# Altium schematic sheet Power.SchDoc
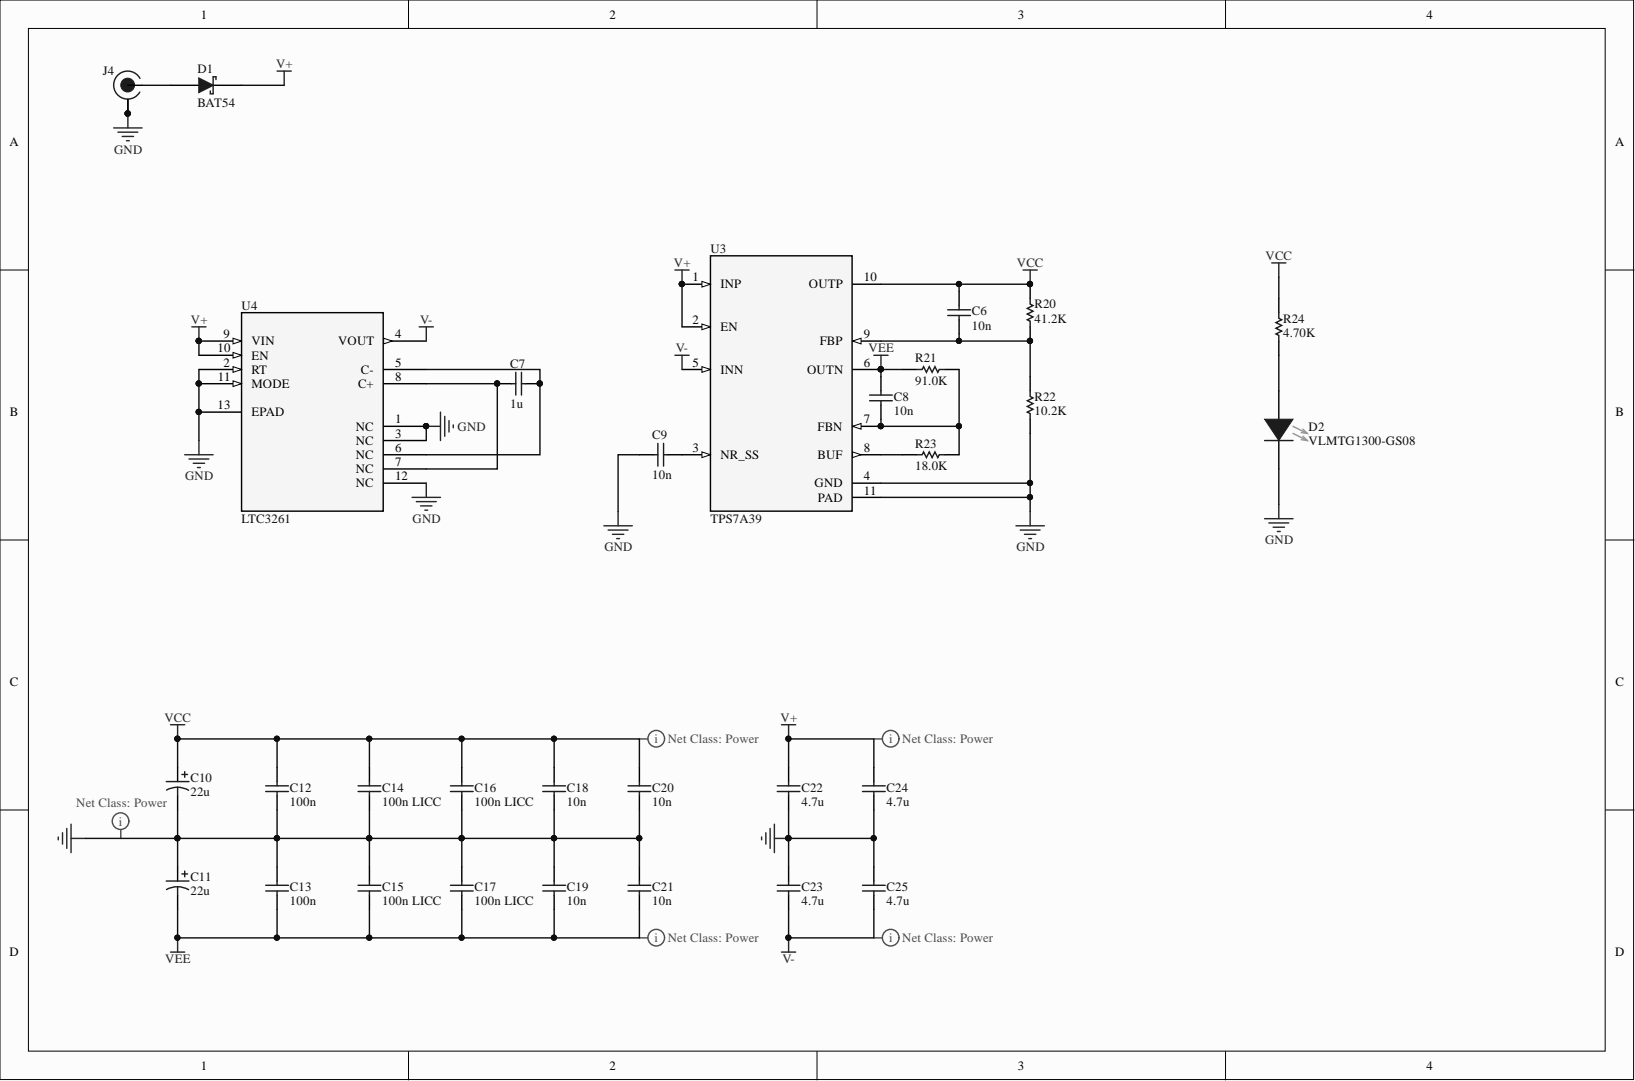
[diagram p0: Power.SchDoc]

## Parts
C6  10n  [CAPC0402(1005)50_M]
C7  1u  [CAPC0805(2012)145_M]
C8  10n  [CAPC0402(1005)50_M]
C9  10n  [CAPC0402(1005)50_M]
C10  22u  [KEMET B_M]
C11  22u  [KEMET B_M]
C12  100n  [CAPC0603(1608)100_M]
C13  100n  [CAPC0603(1608)100_M]
C14  100n LICC  [CAPC0306_M]
C15  100n LICC  [CAPC0306_M]
C16  100n LICC  [CAPC0306_M]
C17  100n LICC  [CAPC0306_M]
C18  10n  [CAPC0402(1005)50_M]
C19  10n  [CAPC0402(1005)50_M]
C20  10n  [CAPC0402(1005)50_M]
C21  10n  [CAPC0402(1005)50_M]
C22  4.7u  [CAPC0805(2012)100_M]
C23  4.7u  [CAPC0805(2012)100_M]
C24  4.7u  [CAPC0805(2012)100_M]
C25  4.7u  [CAPC0805(2012)100_M]
D1  BAT54  [INF-PG-SC59_M]
D2  VLMTG1300-GS08  [LED0603(1608)_M]
J4  2.1/5.5mm  [CUI PJ-002A]  (value not drawn)
R20  41.2K  [RESC0402(1005)_M]
R21  91.0K  [RESC0402(1005)_M]
R22  10.2K  [RESC0402(1005)_M]
R23  18.0K  [RESC0402(1005)_M]
R24  4.70K  [RESC0603(1608)_M]
U3  TPS7A39  [DSC0010J_NV]
U4  LTC3261  [MSOP-12_MSE]

## Nets
GND: C9.2 C10.2 C11.1 C12.2 C13.1 C14.2 C15.1 C16.2 C17.1 C18.2 C19.1 C20.2 C21.1 C22.2 C23.1 C24.2 C25.2 D2.2 J4.2 J4.3 R22.2 U3.4(GND) U3.11(PAD) U4.1(NC) U4.2(RT) U4.3(NC) U4.11(MODE) U4.12(NC) U4.13(EPAD)
V+: C22.1 C24.1 D1.3 U3.1(INP) U3.2(EN) U4.9(VIN) U4.10(EN)
V-: C23.2 C25.1 U3.5(INN) U4.4(VOUT)
VCC: C6.2 C10.1 C12.1 C14.1 C16.1 C18.1 C20.1 R20.1 R24.1 U3.10(OUTP)
VEE: C8.2 C11.2 C13.2 C15.2 C17.2 C19.2 C21.2 R21.2 U3.6(OUTN)
NetC6_1: C6.1 R20.2 R22.1 U3.9(FBP)
NetC7_1: C7.1 U4.7(NC) U4.8(C+)
NetC7_2: C7.2 U4.5(C-) U4.6(NC)
NetC8_1: C8.1 R21.1 R23.1 U3.7(FBN)
NetC9_1: C9.1 U3.3(NR_SS)
NetD1_1: D1.1 J4.1
NetD2_1: D2.1 R24.2
NetR23_2: R23.2 U3.8(BUF)

## Library data of the parts
C6 C8 C9 = GRM1555C1E103JE01: CAP CER 10NF±5% 25V C0G 0402; Manufacturer: Murata; Manufacturer Part Number: GRM1555C1E103JE01D; Capacitance: 10nF; Database Table Name: Murata GRM 0402$; Tolerance: ±5%; Component Kind: Standard; Library Name: Murata GRM 0402.DbLib; Part Number: GRM1555C1E103JE01; Temperature Coefficient: C0G; Value: 10n
C7 = CC0805KKX7R9BB105: Cap Ceramic 1uF 50V X7R 10% SMD 0805 125C Blister T/R; Manufacturer: Yageo; Capacitance: 1uF; Case/Package: 0805; Case Code (Imperial): 0805; Case Code (Metric): 2012; Component Kind: Standard; ComponentLink1Description: http://www.mouser.com/ds/2/447/Yageo_UPY-GP_NP0_16V-to-50V_9-358835.pdf?src-supplier=Mouser; ComponentLink1URL: http://www.mouser.com/ds/2/447/Yageo_UPY-GP_NP0_16V-to-50V_9-358835.pdf?src-supplier=Mouser; Composition: Ceramic; Depth: 1.25mm; Dielectric: X7R; Halogen Free: Halogen Free; Height: 1.25mm; Lead Free: Lead Free; Length: 2mm; Library Name: 0805 Caps.SchLib; Manufacturer Part Number: CC0805KKX7R9BB105; Max Operating Temperature: 125°C; Min Operating Temperature: -55°C; Mount: Surface Mount; Packaging: Tape and Reel; Pricing 1: 1=0.27, 10=0.188, 100=0.1064, 500=0.0751, 1000=0.06571 (USD); Radiation Hardening: No; Stock 1: 558291; Supplier 1: Digi-Key; Supplier 2: Mouser; Supplier Part Number 1: 311-1886-1-ND; Supplier Part Number 2: 603-CC805KKX7R9BB105; Termination: SMD/SMT; Thickness: 0.057inch; Tolerance: 10%; Voltage Rating: 50V; Voltage Rating (DC): 50V; Weight: 0.000194oz; Width: 0.049inch
C10 C11 = T491B226K016AT: CAP TANT 22UF 10% 16V 1411; Manufacturer: KEMET; Dielectric Material: Tantalum; Dissipation Factor: 6%; ESR (Equivalent Series Resistance): 2.2R; Leakage Current: 3.6uA; Life (Hours): 2000Hour; Polarity: Polar; Ripple Current: 197mA; Capacitance: 22uF; Case/Package: 1411; Case Code (Imperial): 1411; Case Code (Metric): 3528; Component Kind: Standard; ComponentLink1Description: https://datasheet.ciiva.com/8079/kem-t2005-t491-8079799.pdf?src-supplier=Element14; ComponentLink1URL: https://datasheet.ciiva.com/8079/kem-t2005-t491-8079799.pdf?src-supplier=Element14; ComponentLink2Description: https://datasheet.ciiva.com/7696/1854511-7696325.pdf?src-supplier=Element14; ComponentLink2URL: https://datasheet.ciiva.com/7696/1854511-7696325.pdf?src-supplier=Element14; ComponentLink3Description: https://datasheet.ciiva.com/7696/1446014-7696326.pdf?src-supplier=Element14; ComponentLink3URL: https://datasheet.ciiva.com/7696/1446014-7696326.pdf?src-supplier=Element14; ComponentLink4Description: https://datasheet.ciiva.com/5934/1446014-5934574.pdf?src-supplier=Farnell; ComponentLink4URL: https://datasheet.ciiva.com/5934/1446014-5934574.pdf?src-supplier=Farnell; ComponentLink5Description: https://datasheet.ciiva.com/6247/kem-t2005-t491-6247978.pdf?src-supplier=Digikey; ComponentLink5URL: https://datasheet.ciiva.com/6247/kem-t2005-t491-6247978.pdf?src-supplier=Digikey; ComponentLink6Description: https://datasheet.ciiva.com/6247/kem-t2005-t491-6247977.pdf?src-supplier=Digikey; ComponentLink6URL: https://datasheet.ciiva.com/6247/kem-t2005-t491-6247977.pdf?src-supplier=Digikey; ComponentLink7Description: https://datasheet.ciiva.com/6247/kem-t2005-t491-6247979.pdf?src-supplier=Digikey; ComponentLink7URL: https://datasheet.ciiva.com/6247/kem-t2005-t491-6247979.pdf?src-supplier=Digikey; ComponentLink8Description: https://datasheet.ciiva.com/14589/70095774-14589317.pdf?src-supplier=Allied+Electronics; ComponentLink8URL: https://datasheet.ciiva.com/14589/70095774-14589317.pdf?src-supplier=Allied+Electronics; ComponentLink9Description: https://datasheet.ciiva.com/14600/70095774-14600348.pdf?src-supplier=Allied+Electronics; ComponentLink9URL: https://datasheet.ciiva.com/14600/70095774-14600348.pdf?src-supplier=Allied+Electronics; ComponentLink10Description: https://datasheet.ciiva.com/12911/kem-t2005-t491-12911727.pdf?src-supplier=Mouser; ComponentLink10URL: https://datasheet.ciiva.com/12911/kem-t2005-t491-12911727.pdf?src-supplier=Mouser; ComponentLink11Description: https://datasheet.ciiva.com/29244/458294-da-01-en-tantal-kondensator-t491b226k016at-29244581.pdf?src-supplier=Conrad; ComponentLink11URL: https://datasheet.ciiva.com/29244/458294-da-01-en-tantal-kondensator-t491b226k016at-29244581.pdf?src-supplier=Conrad; Construction: Flat; Depth: 2.8mm; Failure Rate: 0.001%; Features: General Purpose; Height: 1.9mm; Height - Seated (Max): 0.083inch; Lead Free: Lead Free; Lead Pitch: 1.9mm; Length: 3.5mm; Library Name: Misc Caps.SchLib; Manufacturer Part Number: T491B226K016AT; Max Operating Temperature: 125°C; Min Operating Temperature: -55°C; Mount: Surface Mount,PCB; Number of Pins: 2; Package Quantity: 1; Packaging: Tape and Reel; Pricing 1: 1=0.64, 10=0.433, 50=0.3444, 100=0.2844, 500=0.2222, 1000=0.18887 (USD); Radiation Hardening: No; Reach SVHC Compliant: Yes; RoHS Compliant: Yes; Series: T491; Stock 1: 3174; Supplier 1: Digikey; Supplier 2: Mouser; Supplier Part Number 1: 399-8312-6-ND; Supplier Part Number 2: 80-T491B226K016; Termination: SMD/SMT; Tolerance: 10%; Voltage: 16V; Voltage Rating: 16V; Voltage Rating (DC): 16V; Weight: 0.002293oz; Width: 2.8mm
C12 C13 = CC0603KRX7R9BB104: CAPACITOR CERAMIC, 0.1UF, 50V, X7R, 10%, 0603; Manufacturer: Yageo; Capacitance: 100nF; Case/Package: 0603; Case Code (Imperial): 0603; Case Code (Metric): 1608; Component Kind: Standard; ComponentLink1Description: http://www.yageo.com/documents/recent/UPY-GPHC_X7R_6.3V-to-50V_18.pdf?src-supplier=Digi-Key; ComponentLink1URL: http://www.yageo.com/documents/recent/UPY-GPHC_X7R_6.3V-to-50V_18.pdf?src-supplier=Digi-Key; ComponentLink2Description: http://www.farnell.com/datasheets/1489800.pdf?src-supplier=Element14; ComponentLink2URL: http://www.farnell.com/datasheets/1489800.pdf?src-supplier=Element14; ComponentLink3Description: http://www.yageo.com/documents/recent/UPY-GPHC_X7R_6.3V-to-50V_8.pdf?src-supplier=Avnet+Europe; ComponentLink3URL: http://www.yageo.com/documents/recent/UPY-GPHC_X7R_6.3V-to-50V_8.pdf?src-supplier=Avnet+Europe; ComponentLink4Description: http://www.farnell.com/datasheets/2167236.pdf?src-supplier=Element14; ComponentLink4URL: http://www.farnell.com/datasheets/2167236.pdf?src-supplier=Element14; ComponentLink5Description: http://www.farnell.com/datasheets/1489800.pdf?src-supplier=Farnell; ComponentLink5URL: http://www.farnell.com/datasheets/1489800.pdf?src-supplier=Farnell; Depth: 0.8mm; Dielectric: X7R; Dielectric Material: Ceramic; Halogen Free: Halogen Free; Height: 0.8mm; LCSC PART #: C14663; Lead Free: Lead Free; Length: 1.6mm; Library Name: 0603 Caps.SchLib; Manufacturer Part Number: CC0603KRX7R9BB104; Max Operating Temperature: 125°C; Min Operating Temperature: -55°C; Mount: Surface Mount; Number of Pins: 2; Package Quantity: 1; Packaging: Tape and Reel; Pricing 1: 1=0.1, 10=0.066, 50=0.036, 100=0.0293, 500=0.02094, 1000=0.01646 (USD); Radiation Hardening: No; REACH SVHC: No SVHC; Stock 1: 0; Supplier 1: Digi-Key; Supplier 2: Mouser; Supplier Part Number 1: 311-1344-1-ND; Supplier Part Number 2: 603-CC603KRX7R9BB104; Termination: SMD/SMT; Thickness: 0.035inch; Tolerance: 10%; Voltage: 50V; Voltage Rating: 50V; Width: 0.031inch
C14-C17 = TWK107B7104MVHT: CAP CER 0.1UF 25V X7R 0306; Manufacturer: Taiyo Yuden; Capacitance: 100nF; Tolerance: 20%; Case/Package: 0306; Case Code (Imperial): 0306; Case Code (Metric): 0816; Component Kind: Standard; ComponentLink1Description: http://ds.yuden.co.jp/TYCOMPAS/ut/detail.do?productNo=TWK107B7104MVHT&amp;fileName=TWK107B7104MVHT_SS&amp;mode=specSheetDownload&src-supplier=Digi-Key; ComponentLink1URL: http://ds.yuden.co.jp/TYCOMPAS/ut/detail.do?productNo=TWK107B7104MVHT&amp;fileName=TWK107B7104MVHT_SS&amp;mode=specSheetDownload&src-supplier=Digi-Key; ComponentLink2Description: https://ds.yuden.co.jp/TYCOMPAS/ut/detail?pn=TWK107B7104MVHT &amp;u=M&src-supplier=Digi-Key; ComponentLink2URL: https://ds.yuden.co.jp/TYCOMPAS/ut/detail?pn=TWK107B7104MVHT &amp;u=M&src-supplier=Digi-Key; Library Name: Misc Caps.SchLib; Manufacturer Part Number: TWK107B7104MVHT; Max Operating Temperature: 125°C; Min Operating Temperature: -55°C; Mount: Surface Mount; Packaging: Tape and Reel; Pricing 1: 1=0.31, 10=0.219, 50=0.153, 100=0.1312, 500=0.0984, 1000=0.08309 (USD); Ratings: AEC-Q200; RoHS Compliant: Yes; Stock 1: 9611; Supplier 1: Digi-Key; Supplier 2: Mouser; Supplier Part Number 1: 587-6181-1-ND; Supplier Part Number 2: 963-TWK107B7104MVHT; Voltage Rating: 25V
C18-C21 = GRM155R71H103KA88: CAP CER 10NF±10% 50V X7R 0402; Manufacturer: Murata; Manufacturer Part Number: GRM155R71H103KA88D; Capacitance: 10nF; Database Table Name: Murata GRM 0402$; Tolerance: ±10%; Component Kind: Standard; Library Name: Murata GRM 0402.DbLib; Part Number: GRM155R71H103KA88; Temperature Coefficient: X7R; Value: 10n
C22-C25 = GRM21BZ71H475KE15K: CAP CER 4.7UF 50V X7R 0805; Manufacturer: Murata; Component Kind: Standard; ComponentLink1Description: https://datasheet.octopart.com/GRM21BZ71H475KE15K-Murata-datasheet-149523520.pdf?src-supplier=Newark; ComponentLink1URL: https://datasheet.octopart.com/GRM21BZ71H475KE15K-Murata-datasheet-149523520.pdf?src-supplier=Newark; ComponentLink2Description: https://datasheet.octopart.com/GRM21BZ71H475KE15K-Murata-datasheet-165579666.pdf?src-supplier=TME; ComponentLink2URL: https://datasheet.octopart.com/GRM21BZ71H475KE15K-Murata-datasheet-165579666.pdf?src-supplier=TME; Library Name: 0805 Caps.SchLib; Manufacturer Part Number: GRM21BZ71H475KE15K; Pricing 1: 1=0.6, 10=0.444, 50=0.3554, 100=0.3047, 500=0.2412, 1000=0.20311, 2500=0.19042, 5000=0.17772 (USD); RoHS Compliant: Yes; Stock 1: 134344; Supplier 1: Digi-Key; Supplier 2: Mouser; Supplier Part Number 1: 490-GRM21BZ71H475KE15KCT-ND; Supplier Part Number 2: 81-GRM21BZ71H475KE5K
D1 = BAT54: DIODE SCHOTTKY 30V 200MA TO236AB; Manufacturer: Nexperia USA; Manufacturer Part Number: BAT54,215; Component Kind: Standard; Library Name: Discretes.SchLib; Stock 1: 0; Supplier 1: Digi-Key; Supplier Part Number 1: 1727-2900-6-ND
D2 = VLMTG1300-GS08: LED GREEN 0603 SMD; Manufacturer: Vishay Semiconductors; Dominant Wavelength: 525nm; Forward Current: 20mA; Forward Voltage: 3.2V; Lens Style: Rectangular,Clear; Luminous Intensity: 450mcd; Max Power Dissipation: 76mW; Number of Elements: 1; Power Rating: 75mW; Viewing Angle: 130°; Case/Package: 0603; Case Code (Imperial): 0603; Case Code (Metric): 1608; Color: Green; Component Kind: Standard; ComponentLink1Description: https://datasheet.ciiva.com/26322/vlmo1300-26322149.pdf?src-supplier=Element14; ComponentLink1URL: https://datasheet.ciiva.com/26322/vlmo1300-26322149.pdf?src-supplier=Element14; ComponentLink2Description: https://datasheet.ciiva.com/8028/vlmo1300-8028077.pdf?src-supplier=Element14; ComponentLink2URL: https://datasheet.ciiva.com/8028/vlmo1300-8028077.pdf?src-supplier=Element14; ComponentLink3Description: https://datasheet.ciiva.com/16510/vlmo1300-16510989.pdf?src-supplier=Future+Electronics; ComponentLink3URL: https://datasheet.ciiva.com/16510/vlmo1300-16510989.pdf?src-supplier=Future+Electronics; ComponentLink4Description: https://datasheet.ciiva.com/2115/vlmo1300-2115107.pdf?src-supplier=Digi-Key; ComponentLink4URL: https://datasheet.ciiva.com/2115/vlmo1300-2115107.pdf?src-supplier=Digi-Key; ComponentLink5Description: https://datasheet.ciiva.com/2115/vlmo1300-2115108.pdf?src-supplier=Digi-Key; ComponentLink5URL: https://datasheet.ciiva.com/2115/vlmo1300-2115108.pdf?src-supplier=Digi-Key; ComponentLink6Description: https://datasheet.ciiva.com/2115/vlmo1300-2115106.pdf?src-supplier=Digi-Key; ComponentLink6URL: https://datasheet.ciiva.com/2115/vlmo1300-2115106.pdf?src-supplier=Digi-Key; Contact Plating: Tin,Matte; Depth: 0.8mm; Height: 0.8mm; Illumination Color: Green; Lead Free: Lead Free; Length: 1.6mm; Library Name: Discretes.SchLib; Manufacturer Part Number: VLMTG1300-GS08; Max Operating Temperature: 85°C; Min Operating Temperature: -35°C; Mount: Surface Mount; Number of LEDs: 1; Number of Pins: 2; Package Quantity: 1; Packaging: Tape and Reel; Power Dissipation: 76mW; Pricing 1: 1=0.46, 10=0.305, 100=0.2033, 500=0.1406, 1000=0.11716 (USD); Radiation Hardening: No; RoHS Compliant: Yes; Series: CHipLED; Stock 1: 156996; Supplier 1: Digi-Key; Supplier 2: Mouser; Supplier Part Number 1: VLMTG1300-GS08CT-ND; Supplier Part Number 2: 78-VLMTG1300-GS08; Test Current: 20mA; Wavelength: 525nm; Width: 0.8mm
J4 = PJ-002A: CONN PWR JACK 2X5.5MM SOLDER; Manufacturer: CUI; Current Rating: 2.5A; Gender: Male; Insulation Resistance: 50MR; Number of Conductors: 2; Shielding: Unshielded; Voltage Rating: 24V; Color: Black; Component Kind: Standard; Connector Type: Jack; Contact Gender: Male; Contact Material: Copper Alloy,Copper; Contact Plating: Tin,Nickel; Diameter: 2.1mm; Flammability Rating: UL94 V-0; Housing Color: Black; Housing Material: Thermoplastic; Lead Free: Lead Free; Library Name: Connectors.SchLib; Manufacturer Part Number: PJ-002A; Max Operating Temperature: 85°C; Min Operating Temperature: -25°C; Mount: Through Hole; Orientation: Horizontal; Package Quantity: 500; Packaging: Bulk; Pricing 1: 1=0.6, 10=0.558, 25=0.5024, 50=0.4464, 100=0.4278, 250=0.3906, 500=0.372, 1000=0.3069, 2500=0.279 (USD); Stock 1: 60290; Supplier 1: Digi-Key; Supplier 2: Mouser; Supplier Part Number 1: CP-002A-ND; Supplier Part Number 2: 490-PJ-002A; Termination: Through Hole
R20 = RESC0402-41K2-1pct: RES 41.2K OHM 1% 1/16W 0402; Manufacturer 1: Yageo; Manufacturer Part Number 1: RC0402FR-0741K2L; Database Table Name: R0402-1pct$; Ohms: 41200; Component Kind: Standard; Library Name: R0402 - 1pct.DbLib; Manufacturer 2: Stackpole Electronics; Manufacturer 3: Vishay Dale; Manufacturer 4: Panasonic Electronic Components; Manufacturer Part Number 2: RMCF0402FT41K2; Manufacturer Part Number 3: CRCW040241K2FKED; Manufacturer Part Number 4: ERJ-2RKF4122X; Part Number: RESC0402-41K2-1pct; Value: 41.2K
R21 = RESC0402-91K0-1pct: RES 91.0K OHM 1% 1/16W 0402; Manufacturer 1: Yageo; Manufacturer Part Number 1: RC0402FR-0791KL; Database Table Name: R0402-1pct$; Ohms: 91000; Component Kind: Standard; Library Name: R0402 - 1pct.DbLib; Manufacturer 2: Stackpole Electronics; Manufacturer 3: Vishay Dale; Manufacturer 4: Panasonic Electronic Components; Manufacturer Part Number 2: RMCF0402FT91K0; Manufacturer Part Number 3: CRCW040291K0FKED; Manufacturer Part Number 4: ERJ-2RKF9102X; Part Number: RESC0402-91K0-1pct; Value: 91.0K
R22 = RESC0402-10K2-1pct: RES 10.2K OHM 1% 1/16W 0402; Manufacturer 1: Yageo; Manufacturer Part Number 1: RC0402FR-0710K2L; Database Table Name: R0402-1pct$; Ohms: 10200; Component Kind: Standard; Library Name: R0402 - 1pct.DbLib; Manufacturer 2: Stackpole Electronics; Manufacturer 3: Vishay Dale; Manufacturer 4: Panasonic Electronic Components; Manufacturer Part Number 2: RMCF0402FT10K2; Manufacturer Part Number 3: CRCW040210K2FKED; Manufacturer Part Number 4: ERJ-2RKF1022X; Part Number: RESC0402-10K2-1pct; Value: 10.2K
R23 = RESC0402-18K0-1pct: RES 18.0K OHM 1% 1/16W 0402; Manufacturer 1: Yageo; Manufacturer Part Number 1: RC0402FR-0718KL; Database Table Name: R0402-1pct$; Ohms: 18000; Component Kind: Standard; Library Name: R0402 - 1pct.DbLib; Manufacturer 2: Stackpole Electronics; Manufacturer 3: Vishay Dale; Manufacturer 4: Panasonic Electronic Components; Manufacturer Part Number 2: RMCF0402FT18K0; Manufacturer Part Number 3: CRCW040218K0FKED; Manufacturer Part Number 4: ERJ-2RKF1802X; Part Number: RESC0402-18K0-1pct; Value: 18.0K
R24 = RESC0603-4K70-1pct: RES 4.70K OHM 1% 1/10W 0603; Manufacturer 1: Yageo; Manufacturer Part Number 1: RC0603FR-074K7L; Database Table Name: R0603-1pct$; Ohms: 4700; Component Kind: Standard; Library Name: R0603 - 1pct.DbLib; Manufacturer 2: Stackpole Electronics; Manufacturer 3: Vishay Dale; Manufacturer 4: Panasonic Electronic Components; Manufacturer Part Number 2: RMCF0603FT4K70; Manufacturer Part Number 3: CRCW06034K70FKEA; Manufacturer Part Number 4: ERJ-3EKF4701V; Part Number: RESC0603-4K70-1pct; Value: 4.70K
U3 = TPS7A3901DSCR: IC REG LINEAR POS/NEG ADJ 10WSON; Manufacturer: Texas Instruments; Dropout Voltage: 500mV; Max Input Voltage: 33V; Max Output Voltage: -30V; Max Supply Current: 904uA; Min Output Voltage: 0V; Number of Regulators: 2; Output Current: 150mA; Output Type: Adjustable; Power Supply Rejection Ratio (PSRR): 69dB; Quiescent Current: 150uA; Component Kind: Standard; ComponentLink1Description: http://www.ti.com/lit/ds/symlink/tps7a39.pdf?src-supplier=Digi-Key; ComponentLink1URL: http://www.ti.com/lit/ds/symlink/tps7a39.pdf?src-supplier=Digi-Key; ComponentLink2Description: http://www.ti.com/general/docs/suppproductinfo.tsp?distId=10&amp;gotoUrl=http%3A%2F%2Fwww.ti.com%2Flit%2Fgpn%2Ftps7a39&src-supplier=Digi-Key; ComponentLink2URL: http://www.ti.com/general/docs/suppproductinfo.tsp?distId=10&amp;gotoUrl=http%3A%2F%2Fwww.ti.com%2Flit%2Fgpn%2Ftps7a39&src-supplier=Digi-Key; Library Name: IC.SchLib; Manufacturer_Part_Number: TPS7A3901DSCR; Manufacturer Part Number: TPS7A3901DSCR; Max Operating Temperature: 125°C; Mfr_Name: Texas Instruments; Min Operating Temperature: -40°C; Mount: Surface Mount; Packaging: Cut Tape; RefDes: RefDes; Series: -; Stock 1: 0; Supplier 1: Digi-Key; Supplier 2: Mouser; Supplier Part Number 1: 296-TPS7A3901DSCRCT-ND; Supplier Part Number 2: 595-TPS7A3901DSCR; Type: DEV; Copyright: Copyright (C) 2022 Ultra Librarian. All rights reserved.
U4 = LTC3261EMSE: IC REG CHARG PUMP INV 12MSOP; Manufacturer: Analog Devices / Linear Technology; Manufacturer_Part_Number: ltc3261emse#pbf; Manufacturer Part Number: LTC3261EMSE#TRPBF; Mfr_Name: Linear Technology; Max Input Voltage: 32V; Max Output Current: 100mA; Min Input Voltage: 4.5V; Number of Outputs: 1; Operating Supply Current: 60uA; Output Type: Adjustable; Output Voltage: -32V; Switching Frequency: 500kHz; Synchronous Rectifier: No; Case/Package: SOP; Component Kind: Standard; ComponentLink1Description: http://components.arrow.com/part/dataview/53470050S89425N7433?src-supplier=Arrow+Electronics; ComponentLink1URL: http://components.arrow.com/part/dataview/53470050S89425N7433?src-supplier=Arrow+Electronics; ComponentLink2Description: http://cds.linear.com/docs/en/datasheet/3261fb.pdf?src-supplier=Digi-Key; ComponentLink2URL: http://cds.linear.com/docs/en/datasheet/3261fb.pdf?src-supplier=Digi-Key; ComponentLink3Description: http://www.linear.com/docs/44087?src-supplier=Digi-Key; ComponentLink3URL: http://www.linear.com/docs/44087?src-supplier=Digi-Key; Lead Free: Lead Free; Library Name: IC.SchLib; Max Operating Temperature: 125°C; Max Supply Voltage: 32V; Min Operating Temperature: -40°C; Min Supply Voltage: 4.5V; Number of Pins: 12; Output Current: 100mA; Package Quantity: 2500; Packaging: Tape and Reel; RefDes: RefDes; RoHS Compliant: Yes; Series: -; Stock 1: 0; Supplier 1: Digi-Key; Supplier 2: Mouser; Supplier 3: Newark; Supplier Part Number 1: LTC3261EMSE#TRPBFCT-ND; Supplier Part Number 2: 584-LTC3261EMSETRPBF; Supplier Part Number 3: 50AK2864; Type: DEV; Copyright: Copyright (C) 2022 Ultra Librarian. All rights reserved.
